# Altium schematic sheet Power.SchDoc(Power)
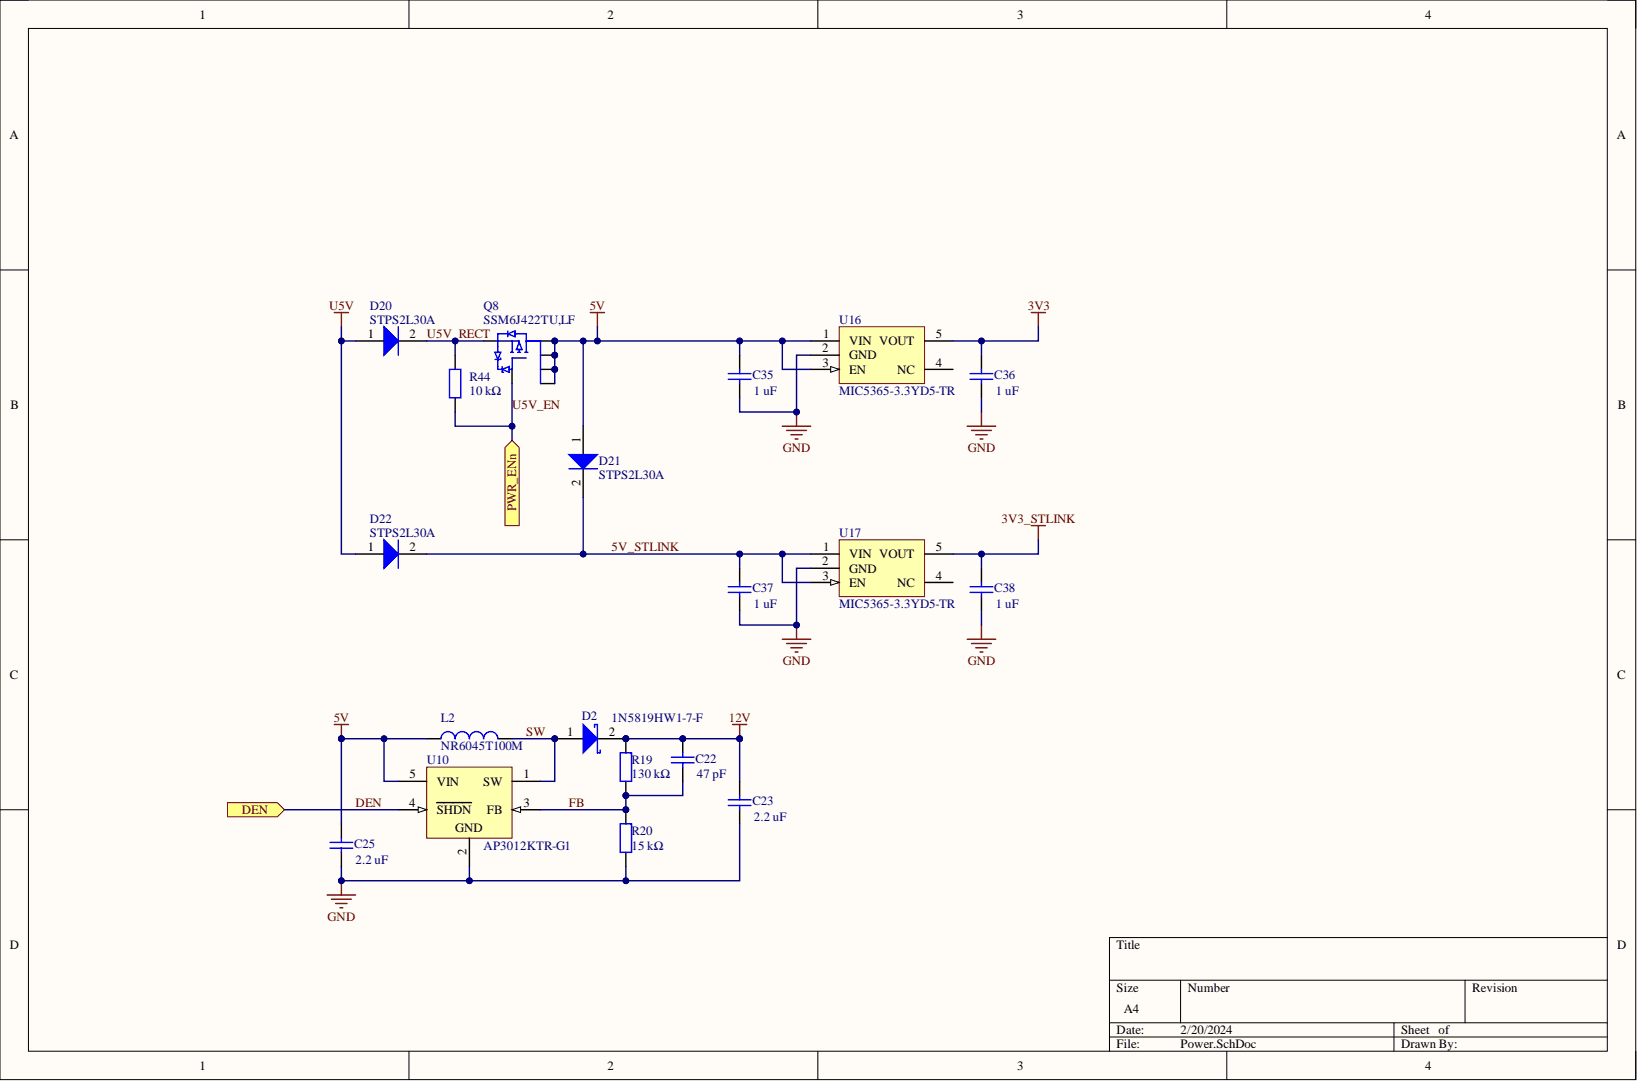
[diagram p0: Power.SchDoc]

## Parts
C22  Capacitance: 47 pF  [PCB-gvhn8eevp3bcfemrj54e-1]  (hidden comment: Yageo CC0603JRNPO7BN470)
C23  Capacitance: 2.2 uF  (hidden comment: Yageo CC0603KRX5R8BB225)
C25  Capacitance: 2.2 uF  (hidden comment: Yageo CC0603KRX5R8BB225)
C35  Capacitance: 1 uF  (hidden comment: Yageo CC0603ZRY5V8BB105)
C36  Capacitance: 1 uF  (hidden comment: Yageo CC0603ZRY5V8BB105)
C37  Capacitance: 1 uF  (hidden comment: Yageo CC0603ZRY5V8BB105)
C38  Capacitance: 1 uF  (hidden comment: Yageo CC0603ZRY5V8BB105)
D2  1N5819HW1-7-F
D20  STPS2L30A
D21  Manufacturer Part Number: STPS2L30A  (hidden comment: STMicroelectronics STPS2L30A)
D22  Manufacturer Part Number: STPS2L30A  (hidden comment: STMicroelectronics STPS2L30A)
L2  Manufacturer Part Number: NR6045T100M  (hidden comment: Taiyo Yuden NR6045T100M)
Q8  Manufacturer Part Number: SSM6J422TU,LF  (hidden comment: Toshiba SSM6J422TU,LF)
R19  Yageo RC0603FR-07130KL  (value not drawn)
R20  Resistance: 15 kΩ  (hidden comment: Yageo RC0603FR-0715KL)
R44  Resistance: 10 kΩ  (hidden comment: Yageo RC0603FR-0710KL)
U10  Manufacturer Part Number: AP3012KTR-G1  (hidden comment: Diodes Inc. AP3012KTR-G1)
U16  Manufacturer Part Number: MIC5365-3.3YD5-TR  (hidden comment: Microchip MIC5365-3.3YD5-TR)
U17  Manufacturer Part Number: MIC5365-3.3YD5-TR  (hidden comment: Microchip MIC5365-3.3YD5-TR)

## Ports
DEN, PWR_ENn

## Nets
3V3: C36.1 U16.5(VOUT)
3V3_STLINK: C38.1 U17.5(VOUT)
5V: C25.1 C35.1 D21.1 L2.1 Q8.1 Q8.2 Q8.5 Q8.6 U10.5(VIN) U16.1(VIN) U16.3(EN)
12V: C22.1 C23.1 D2.2 R19.2
GND: C23.2 C25.2 C35.2 C36.2 C37.2 C38.2 R20.1 U10.2 U16.2(GND) U17.2(GND)
U5V: D20.1 D22.1
5V_STLINK: C37.1 D21.2 D22.2 U17.1(VIN) U17.3(EN)
DEN: U10.4(SHDN) ; port DEN
FB: C22.2 R19.1 R20.2 U10.3(FB)
SW: D2.1 L2.2 U10.1(SW)
U5V_EN: Q8.3 R44.1 ; port PWR_ENn
U5V_RECT: D20.2 Q8.4 R44.2

Not connected: U16 4(NC); U17 4(NC)

## Library data of the parts
C22 = CMP-f2f83b8d074c2d3f-7: 0603 47pF 25 V ±5 % Tolerance C0G SMT Multilayer Ceramic Capacitor; Manufacturer Part Number: CC0603JRNPO7BN200; Capacitance Tolerance: ±5%; Lead-Free Status: Lead Free; Voltage Rating (DC): 25 V; Case/Package: 0603, 1608; Component Kind: Standard; Dielectric Characteristic: C0G; Library Name: My Personal Space; Mounting Style: Surface Mount; Number of Pins: 2; Octopart Url: http://octopart.com/cc0603krx5r7bb105-yageo-8376530; OctopartID: f2f83b8d074c2d3f; Packaging: Cut Tape (CT); RoHS: Compliant; Seller Landing  Url: https://octopart-clicks.com/click/track?country=US&ak=8157f166&sig=0aaebbd&sid=1106&ppid=8376530&vpid=198824008&ct=offers
C23 C25 = CMP-f2f83b8d074c2d3f-7: 0603 2.2 uF 25 V 10% Tolerance X5R SMT Multilayer Ceramic Capacitor; Lead-Free Status: Lead Free; Voltage Rating (DC): 25 V; Capacitance Tolerance: 10%; Case/Package: 0603, 1608; Component Kind: Standard; Dielectric Characteristic: X5R; Library Name: My Personal Space; Manufacturer Part Number: CC0603KRX5R8BB225; Mounting Style: Surface Mount; Number of Pins: 2; Octopart Url: http://octopart.com/cc0603krx5r7bb105-yageo-8376530; OctopartID: f2f83b8d074c2d3f; Packaging: Cut Tape (CT); RoHS: Compliant; Seller Landing  Url: https://octopart-clicks.com/click/track?country=US&ak=8157f166&sig=0aaebbd&sid=1106&ppid=8376530&vpid=198824008&ct=offers
C35-C38 = CMP-f2f83b8d074c2d3f-7: 0603 1 uF 25 V +80%/-20% Tolerance Y5V SMT Multilayer Ceramic Capacitor; Lead-Free Status: Lead Free; Voltage Rating (DC): 25 V; Capacitance Tolerance: +80%/-20%; Case/Package: 0603, 1608; Component Kind: Standard; Dielectric Characteristic: Y5V; Library Name: My Personal Space; Manufacturer Part Number: CC0603ZRY5V8BB105; Mounting Style: Surface Mount; Number of Pins: 2; Octopart Url: http://octopart.com/cc0603krx5r7bb105-yageo-8376530; OctopartID: f2f83b8d074c2d3f; Packaging: Cut Tape (CT); RoHS: Compliant; Seller Landing  Url: https://octopart-clicks.com/click/track?country=US&ak=8157f166&sig=0aaebbd&sid=1106&ppid=8376530&vpid=198824008&ct=offers
D2 = CMP-f1953c9df3432e52-4: 1N5819 Schottky Diode 40V 1A SOD123F; Component Kind: Standard; Library Name: CommunityVault; Manufacturer Part Number: 1N5819HW1-7-F
D20-D22 = CMP-816813-4: STPS2L30 Series 2 A 0.45 V Schottky Rectifier Surface Mount - DO-214AC; Current Rating: 2.00 A; Forward Voltage: 450 mV; Lead-Free Status: Lead Free; Output Current: 2.00 A (max); Polarity: Standard; Voltage Rating (DC): 30.0 V; Component Kind: Standard; Library Name: My Personal Space; Lifecycle Status: Active; Mounting Style: Surface Mount; Number of Pins: 2; Octopart Url: http://octopart.com/stps2l30a-stmicroelectronics-324369; OctopartID: 573a9e34814d88fd; Packaging: Cut Tape (CT); REACH SVHC Compliance: No SVHC; RoHS: Compliant; Seller Landing  Url: https://octopart-clicks.com/click/track?country=US&ak=8157f166&sig=022b0b7&sid=1106&ppid=324369&vpid=37482466&ct=offers
  D20: Manufacturer Part Number: STPS2L30A
L2 = CMP-531f9a9645bf8cd8-1: Inductor Power Shielded Wirewound 10uH 20% 100KHz Ferrite 2.5A 0.0611Ohm DCR 2424 T/R; Inductance: 10.0 µH; Lead-Free Status: Lead Free; Self Resonant Frequency: 12.0 MHz; Component Kind: Standard; Halogen Free Status: Halogen Free; Library Name: CommunityVault; Lifecycle Status: Active; Mounting Style: Surface Mount; Number of Pins: 2; Octopart URL: https://octopart.com/nr6045t100m-taiyo+yuden-8598470; OctopartID: 531f9a9645bf8cd8; Packaging: Reel; RoHS: Compliant
Q8 = CMP-b5507a75d9776a97-1: Transistor MOSFET P-Channel 20V 4A 6-Pin UF; Component Kind: Standard; Library Name: My Personal Space; Octopart Url: https://octopart.com/ssm6j422tu%2Clf-toshiba-103762241; OctopartID: b5507a75d9776a97
R19 = CMP-9abf51c576ad4578-19: Res General Purpose Thick Film 0603 130k Ohm 1% 1/10W ±100ppm/°C Molded Paper T/R; Case/Package (SI): 1608; Lead-Free Status: Lead Free; Power Rating: 100 mW; Resistance: 130 kΩ; Temperature Coefficient: -100 ppm/°C to 100 ppm/°C; Voltage Rating (DC): 75.0 V, 50.0 V; Case/Package: 0603; Component Kind: Standard; Library Name: CommunityVault; Manufacturer Part Number: RC0603FR-07130KL; Mounting Style: Surface Mount; Number of Pins: 2; Octopart URL: https://octopart.com/rc0603fr-07100kl-yageo-40946036; OctopartID: 9abf51c576ad4578; Operating Temperature: 155 °C (max); Packaging: Tape & Reel; REACH SVHC Compliance: No SVHC; Resistance Tolerance: ±1%; RoHS: Compliant; Size-Height: 450 µm; Size-Length: 1.60 mm; Size-Width: 800 µm
R20 = CMP-9abf51c576ad4578-19: Res General Purpose Thick Film 0603 15k Ohm 1% 1/10W ±100ppm/°C Molded Paper T/R; Case/Package (SI): 1608; Lead-Free Status: Lead Free; Power Rating: 100 mW; Temperature Coefficient: -100 ppm/°C to 100 ppm/°C; Voltage Rating (DC): 75.0 V, 50.0 V; Case/Package: 0603; Component Kind: Standard; Library Name: CommunityVault; Manufacturer Part Number: RC0603FR-0715KL; Mounting Style: Surface Mount; Number of Pins: 2; Octopart URL: https://octopart.com/rc0603fr-07100kl-yageo-40946036; OctopartID: 9abf51c576ad4578; Operating Temperature: 155 °C (max); Packaging: Tape & Reel; REACH SVHC Compliance: No SVHC; Resistance Tolerance: ±1%; RoHS: Compliant; Size-Height: 450 µm; Size-Length: 1.60 mm; Size-Width: 800 µm
R44 = CMP-9abf51c576ad4578-19: Res General Purpose Thick Film 0603 10k Ohm 1% 1/10W ±100ppm/°C Molded Paper T/R; Case/Package (SI): 1608; Lead-Free Status: Lead Free; Power Rating: 100 mW; Temperature Coefficient: -100 ppm/°C to 100 ppm/°C; Voltage Rating (DC): 75.0 V, 50.0 V; Case/Package: 0603; Component Kind: Standard; Library Name: CommunityVault; Manufacturer Part Number: RC0603FR-0710KL; Mounting Style: Surface Mount; Number of Pins: 2; Octopart URL: https://octopart.com/rc0603fr-07100kl-yageo-40946036; OctopartID: 9abf51c576ad4578; Operating Temperature: 155 °C (max); Packaging: Tape & Reel; REACH SVHC Compliance: No SVHC; Resistance Tolerance: ±1%; RoHS: Compliant; Size-Height: 450 µm; Size-Length: 1.60 mm; Size-Width: 800 µm
U10 = CMP-576a9fb826ae88ad-7: AP3012 Series 16 V 500 mA 1.5 MHz Surface Mount Boost DC-DC Converter - SOT-25; Lead-Free Status: Lead Free; Component Kind: Standard; Library Name: CommunityVault; Lifecycle Status: Not Listed by Manufacturer; Mounting Style: Surface Mount; Octopart URL: https://octopart.com/ap3012ktr-g1-diodes+inc.-30954658; OctopartID: 576a9fb826ae88ad; Packaging: Tape & Reel (TR); RoHS: Compliant
U16 U17 = CMP-c6150ce4b57919d2-2: Power Management, Voltage Regulators, pin, regulator, Semiconductors and Actives, ic, reg; Component Kind: Standard; Homepage URL: http://www.microchip.com/wwwproducts/en/MIC5365; Library Name: My Personal Space; Lifecycle Status: Not Listed by Manufacturer; Manufacturer: Microchip; Octopart URL: https://octopart.com/mic5365-3.3yd5+t5-micrel-24222979; OctopartID: c6150ce4b57919d2
